# Altium schematic sheet Sheet3.SchDoc(Sheet3)
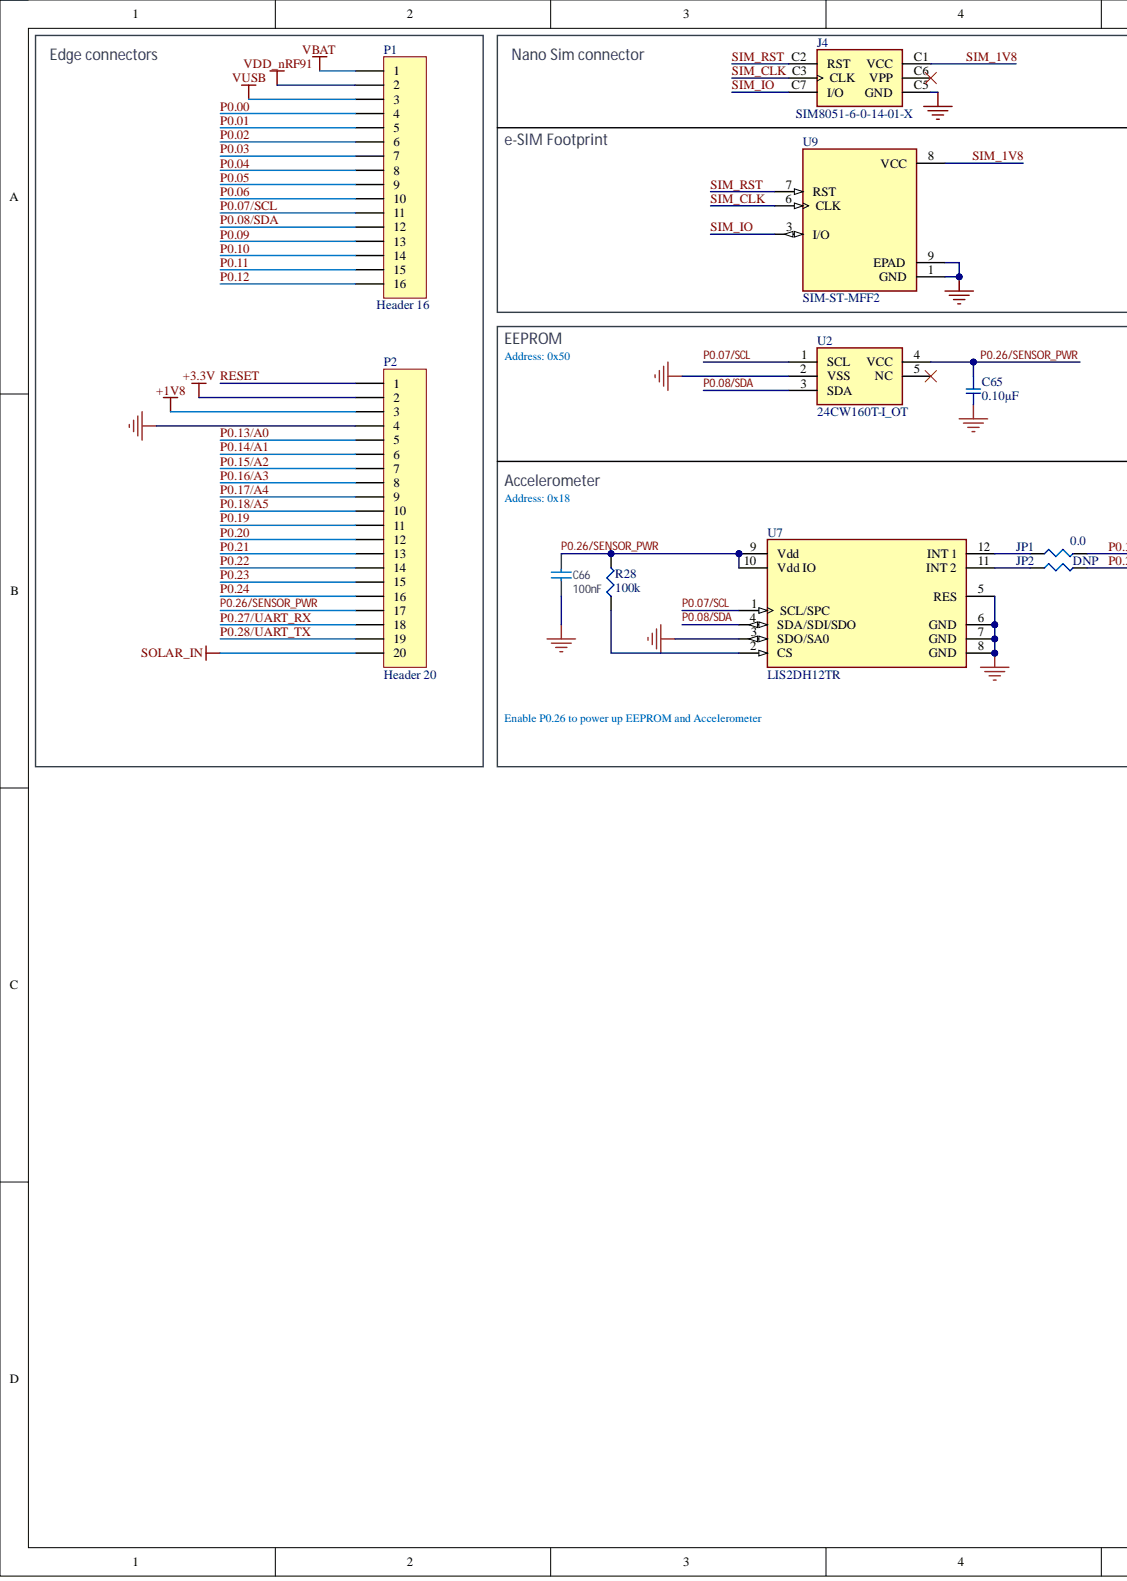
[diagram p0: Sheet3.SchDoc, left]
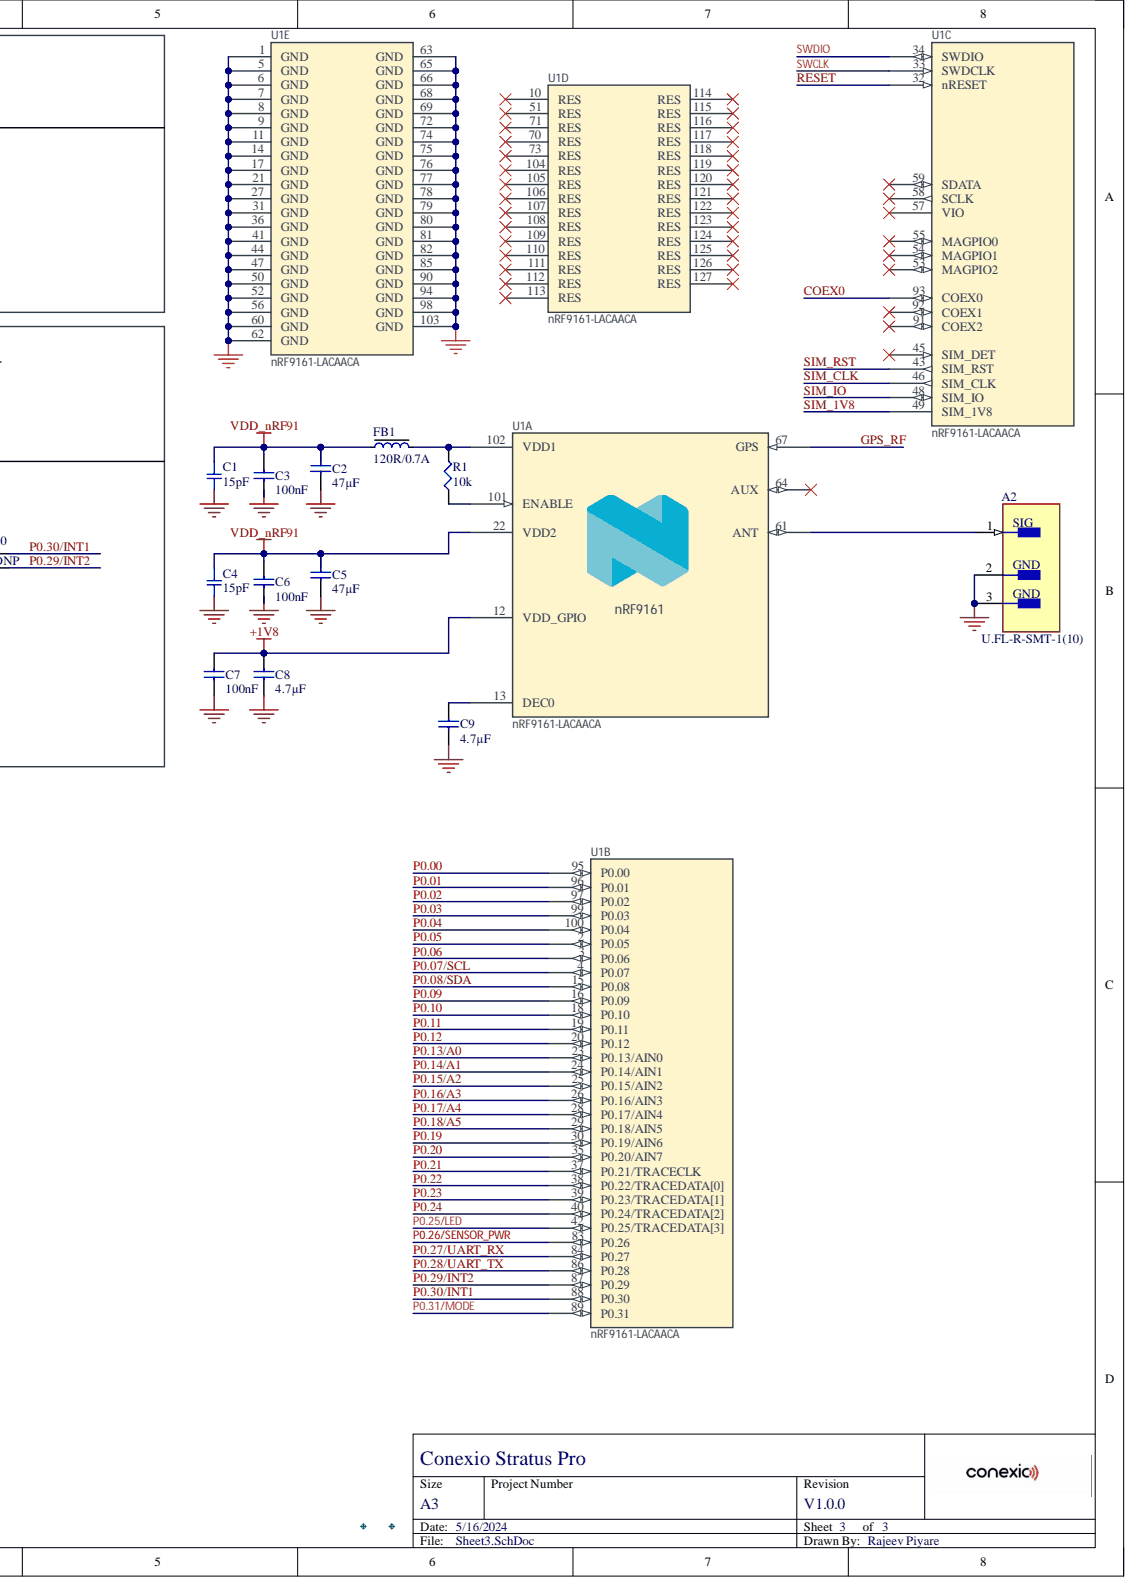
[diagram p1: Sheet3.SchDoc, right]

## Parts
A2  U.FL-R-SMT-1(10)
C1  15pF
C2  47µF
C3  100nF
C4  15pF
C5  47µF
C6  100nF
C7  100nF
C8  4.7µF
C9  4.7µF
C65  0.10µF
C66  100nF
FB1  120R/0.7A
J4  SIM8051-6-0-14-01-X
JP1  0.0
JP2  DNP
P1  Header 16
P2  Header 20
R1  10k
R28  100k
U1  nRF9161-LACAACA  (parts A,B,C,D,E)
U2  24CW160T-I_OT
U7  LIS2DH12TR
U9  SIM-ST-MFF2

## Nets
+1V8: C7.1 C8.1 P2.3 U1.12(VDD_GPIO)
+3.3V: P2.2
GND: A2.2 A2.3 C1.2 C2.2 C3.2 C4.2 C5.2 C6.2 C7.2 C8.2 C9.2 C65.2 C66.1 J4.C5(GND) P2.4 U1.1(GND) U1.5(GND) U1.6(GND) U1.7(GND) U1.8(GND) U1.9(GND) U1.11(GND) U1.14(GND) U1.17(GND) U1.21(GND) U1.27(GND) U1.31(GND) U1.36(GND) U1.41(GND) U1.44(GND) U1.47(GND) U1.50(GND) U1.52(GND) U1.56(GND) U1.60(GND) U1.62(GND) U1.63(GND) U1.65(GND) U1.66(GND) U1.68(GND) U1.69(GND) U1.72(GND) U1.74(GND) U1.75(GND) U1.76(GND) U1.77(GND) U1.78(GND) U1.79(GND) U1.80(GND) U1.81(GND) U1.82(GND) U1.85(GND) U1.90(GND) U1.94(GND) U1.98(GND) U1.103(GND) U2.2(VSS) U7.3(SDO/SA0) U7.5(RES) U7.6(GND) U7.7(GND) U7.8(GND) U9.1(GND) U9.9(EPAD)
SOLAR_IN: P2.20
VBAT: P1.1
VDD_nRF91: C1.1 C2.1 C3.1 C4.1 C5.1 C6.1 FB1.1 P1.2 U1.22(VDD2)
VUSB: P1.3
COEX0: U1.93(COEX0)
GPS_RF: U1.67(GPS)
P0.00: P1.4 U1.95(P0.00)
P0.01: P1.5 U1.96(P0.01)
P0.02: P1.6 U1.97(P0.02)
P0.03: P1.7 U1.99(P0.03)
P0.04: P1.8 U1.100(P0.04)
P0.05: P1.9 U1.2(P0.05)
P0.06: P1.10 U1.3(P0.06)
P0.07/SCL: P1.11 U1.4(P0.07) U2.1(SCL) U7.1(SCL/SPC)
P0.08/SDA: P1.12 U1.15(P0.08) U2.3(SDA) U7.4(SDA/SDI/SDO)
P0.09: P1.13 U1.16(P0.09)
P0.10: P1.14 U1.18(P0.10)
P0.11: P1.15 U1.19(P0.11)
P0.12: P1.16 U1.20(P0.12)
P0.13/A0: P2.5 U1.23(P0.13/AIN0)
P0.14/A1: P2.6 U1.24(P0.14/AIN1)
P0.15/A2: P2.7 U1.25(P0.15/AIN2)
P0.16/A3: P2.8 U1.26(P0.16/AIN3)
P0.17/A4: P2.9 U1.28(P0.17/AIN4)
P0.18/A5: P2.10 U1.29(P0.18/AIN5)
P0.19: P2.11 U1.30(P0.19/AIN6)
P0.20: P2.12 U1.35(P0.20/AIN7)
P0.21: P2.13 U1.37(P0.21/TRACECLK)
P0.22: P2.14 U1.38(P0.22/TRACEDATA[0])
P0.23: P2.15 U1.39(P0.23/TRACEDATA[1])
P0.24: P2.16 U1.40(P0.24/TRACEDATA[2])
P0.25/LED: U1.42(P0.25/TRACEDATA[3])
P0.26/SENSOR_PWR: C65.1 C66.2 P2.17 R28.2 U1.83(P0.26) U2.4(VCC) U7.9(Vdd) U7.10(Vdd_IO)
P0.27/UART_RX: P2.18 U1.84(P0.27)
P0.28/UART_TX: P2.19 U1.86(P0.28)
P0.29/INT2: JP2.2 U1.87(P0.29)
P0.30/INT1: JP1.2 U1.88(P0.30)
P0.31/MODE: U1.89(P0.31)
RESET: P2.1 U1.32(nRESET)
SIM_1V8: J4.C1(VCC) U1.49(SIM_1V8) U9.8(VCC)
SIM_CLK: J4.C3(CLK) U1.46(SIM_CLK) U9.6(CLK)
SIM_IO: J4.C7(I/O) U1.48(SIM_IO) U9.3(I/O)
SIM_RST: J4.C2(RST) U1.43(SIM_RST) U9.7(RST)
SWCLK: U1.33(SWDCLK)
SWDIO: U1.34(SWDIO)
NetA2_1: A2.1 U1.61(ANT)
NetC9_1: C9.1 U1.13(DEC0)
NetFB1_2: FB1.2 R1.2 U1.102(VDD1)
NetJP1_1: JP1.1 U7.12(INT_1)
NetJP2_1: JP2.1 U7.11(INT_2)
NetR1_1: R1.1 U1.101(ENABLE)
NetR28_1: R28.1 U7.2(CS)

Not connected: J4 C6(VPP); U1 10(RES) 45(SIM_DET) 51(RES) 53(MAGPIO2) 54(MAGPIO1) 55(MAGPIO0) 57(VIO) 58(SCLK) 59(SDATA) 64(AUX) 70(RES) 71(RES) 73(RES) 91(COEX2) 92(COEX1) 104(RES) 105(RES) 106(RES) 107(RES) 108(RES) 109(RES) 110(RES) 111(RES) 112(RES) 113(RES) 114(RES) 115(RES) 116(RES) 117(RES) 118(RES) 119(RES) 120(RES) 121(RES) 122(RES) 123(RES) 124(RES) 125(RES) 126(RES) 127(RES); U2 5(NC)

## Library data of the parts
A2 = CMP-2000-05152-3: CONN U.FL RCPT STR 50 OHM SMD; Body Material: Bronze; Body Plating: Silver; Connector Type: Coaxial; Dielectric Material: Polymer; Frequency: 6GHz; Gender: Male; Impedance: 50R; Insulation Resistance: 500MR; Component Kind: Standard; Contact Material: Brass; Contact Plating: Gold; Depth: 3.1mm; Fastening Type: Snap; Housing Color: Beige; Lead Free: Lead Free; Length: 3mm; Library Name: Altium Content Vault; Max Frequency: 6GHz; Max Operating Temperature: 90°C; Min Operating Temperature: -40°C; Mount: Surface Mount; Orientation: Straight; Package Quantity: 2500; Packaging: Cut Tape; Radiation Hardening: No; REACH SVHC: No SVHC; Series: U.FL; Termination: Solder; Weight: 0.001764oz; Wire/Cable Type: Coaxial
C1 C4 = GCM1885C1H150JA16D: CAP CER 15PF 50V NP0 0603; Manufacturer: Murata Electronics North America; @ qty: 0; Minimum Quantity: 1; Temperature Coefficient: C0G, NP0; Tolerance: ±5%; Unit Price (USD): 0.1; Applications: Automotive; Component Kind: Standard; Datasheets: http://www.murata.com/~/media/webrenewal/support/library/catalog/products/capacitor/mlcc/c03e.ashx; Digi-Key Part Number: 490-4957-1-ND; Factory Stock: 0; Features: -; Height - Seated (Max): -; Image: //media.digikey.com/Renders/Murata%20Renders/0603%28GCM188%29.jpg; Lead Spacing: -; Lead Style: -; Library Name: capacitor_murata_0603.IntLib; Mounting Type: Surface Mount, MLCC; Operating Temperature: -55°C ~ 125°C; Package / Case: 0603 (1608 Metric); Packaging: Cut Tape (CT); Part Number: GCM1885C1H150JA16D; Part Status: Active; Quantity Available: 99209; Ratings: AEC-Q200; Series: GCM; Size / Dimension: 0.063" L x 0.031" W (1.60mm x 0.80mm); Thickness (Max): 0.035" (0.90mm); Value: 15pF; Voltage: 50V
C2 C5 = C0805-47µ-10V: Capacitor, X5R, ±20%, 10V; Manufacturer: Murata; Manufacturer Part Number: GRM21BR61A476ME15; Part number IPS: 00000004759; Artikkelnr Noca: N.A.; Database Table Name: Parts$; Pad Count: 2; Component Class: Capacitors; Component Kind: Standard; ComponentLink1Description: Datasheet; ComponentLink1URL: https://search.murata.co.jp/Ceramy/image/img/A01X/G101/ENG/GRM21BR61A476ME15-01A.pdf; ComponentLink2Description: Supplier link; ComponentLink2URL: N.A.; Footprint Doc: 0805; HelpURL: https://www.murata.com/en-global/products/productdetail?partno=GRM21BR61A476ME15%23; LatestRevisionDate: 2020-10-23; Library Name: AltiumLib.DbLib; Note: 10V; Part Number: C0805-47µ-10V; PartId: 100200288; Published: 2020-08-14; Supplier 1: Digi-Key; Supplier 2: N.A.; Supplier Part Number 1: 490-9961-1-ND; Supplier Part Number 2: N.A.; Value: 47µF
C3 C6 C7 = C0201-100n: Capacitor, X5R, ±10%; Part number IPS: 00000001248, 00000002505; Artikkelnr Noca: N.A.; Database Table Name: Parts$; Note: 16V; Pad Count: 2; Component Class: Capacitors; Component Kind: Standard; ComponentLink1Description: Datasheet; ComponentLink1URL: N.A.; ComponentLink2Description: Supplier link; ComponentLink2URL: N.A.; Footprint Doc: 0201; HelpURL: N.A.; LatestRevisionDate: 2017-11-09; Library Name: AltiumLib.DbLib; Manufacturer: N.A.; Manufacturer Part Number: N.A.; Part Number: C0201-100n; PartId: 100200078; Published: 2014-11-13; Supplier 1: N.A.; Supplier 2: N.A.; Supplier Part Number 1: N.A.; Supplier Part Number 2: N.A.; Value: 100nF
C8 C9 = C0603-4µ7: Capacitor, X5R, ±10%; Manufacturer: N.A.; Part number IPS: 00000007783; Artikkelnr Noca: 20069060; Database Table Name: Parts$; Note: 6.3V; Pad Count: 2; Component Class: Capacitors; Component Kind: Standard; ComponentLink1Description: Datasheet; ComponentLink1URL: N.A.; ComponentLink2Description: Supplier link; ComponentLink2URL: N.A.; Footprint Doc: 0603; HelpURL: N.A.; LatestRevisionDate: 2009-11-23; Library Name: AltiumLib.DbLib; Manufacturer Part Number: N.A.; Part Number: C0603-4µ7; PartId: 100200274; Published: 2009-05-08; Supplier 1: N.A.; Supplier 2: N.A.; Supplier Part Number 1: N.A.; Supplier Part Number 2: N.A.; Value: 4.7µF
C65 = GCJ188R71H104KA12D: CAP CER 0.1UF 50V X7R 0603; Manufacturer: Murata Electronics North America; @ qty: 0; Minimum Quantity: 1; Tolerance: ±10%; Unit Price (USD): 0.12; Applications: Automotive, Boardflex Sensitive; Component Kind: Standard; Datasheets: http://www.murata.com/~/media/webrenewal/support/library/catalog/products/capacitor/mlcc/c03e.ashx; Digi-Key Part Number: 490-5798-1-ND; Factory Stock: 0; Features: Soft Termination; Height - Seated (Max): -; Image: //media.digikey.com/Renders/Murata%20Renders/0603%28LQG18%29.jpg; Lead Spacing: -; Lead Style: -; Library Name: capacitor_murata_0603.IntLib; Mounting Type: Surface Mount, MLCC; Operating Temperature: -55°C ~ 125°C; Package / Case: 0603 (1608 Metric); Packaging: Cut Tape (CT); Part Number: GCJ188R71H104KA12D; Part Status: Active; Quantity Available: 163695; Ratings: AEC-Q200; Series: GCJ; Size / Dimension: 0.063" L x 0.031" W (1.60mm x 0.80mm); Temperature Coefficient: X7R; Thickness (Max): 0.035" (0.90mm); Value: 0.10µF; Voltage: 50V
C66 = C0201-100n-16V-X6S-MSASE063AC6104KFNA01: Capacitor, X6S, ±10%, 16V; Manufacturer: Taiyo Yuden; Part number NEMS: 100200544; Artikkelnr Noca: N.A.; Component Status: Active; Database Table Name: Parts$; Pad Count: 2; Component Class: Capacitors; Component Kind: Standard; ComponentLink1Description: Datasheet; ComponentLink1URL: https://www.yuden.co.jp/productdata/catalog/mlcc06_e.pdf; ComponentLink2Description: Supplier link; ComponentLink2URL: N.A.; Footprint Doc: 0201; HelpURL: https://ds.yuden.co.jp/TYCOMPAS/ut/detail?pn=MSASE063AC6104KFNA01&u=M; LatestRevisionDate: 2023-03-22; Library Name: AltiumLib.DbLib; Manufacturer Part Number: MSASE063AC6104KFNA01; Note: 16V; Part Number: C0201-100n-16V-X6S-MSASE063AC6104KFNA01; Part number IPS: N.A.; Part number Norautron: N.A.; PartId: 100200544; Published: 2023-03-22; Supplier 1: Digi-Key; Supplier 2: N.A.; Supplier Part Number 1: 587-6001-1-ND; Supplier Part Number 2: N.A.; Value: 100nF; Author: rubr
FB1 = FB0402-120R@100M-700mA: Ferrite Bead, 120 Ohm @ 100MHz, 700mA, 130 mOhm Max; Manufacturer: Murata; Manufacturer Part Number: BLM15AX121SN1; Part number IPS: 00000004487; Artikkelnr Noca: N.A.; Database Table Name: Parts$; Pad Count: 2; Component Class: Inductors; Component Kind: Standard; ComponentLink1Description: Datasheet; ComponentLink1URL: https://www.murata.com/en-us/products/productdata/8796740059166/ENFA0018.pdf; ComponentLink2Description: Supplier link; ComponentLink2URL: N.A.; Footprint Doc: 0402; HelpURL: https://www.murata.com/products/productdetail?partno=BLM15AX121SN1%23; LatestRevisionDate: 2020-04-28; Library Name: AltiumLib.DbLib; Note: N.A.; Part Number: FB0402-120R@100M-700mA; PartId: 100300100; Published: 2020-04-28; Supplier 1: Digi-Key; Supplier 2: N.A.; Supplier Part Number 1: 490-5439-1-ND; Supplier Part Number 2: N.A.; Value: 120R/0.7A
J4 = SIM8051-6-0-14-01-X-REVD: 6 Position Card Connector NANO SIM Surface Mount, Right Angle Gold; MF: Global Connector Technology; MP: SIM8051-6-0-14-01-A; Availability: Unavailable; Component Kind: Standard; Library Name: SIM8051-6-0-14-01-X-REVD.SchLib; Package: None; Price: None; SnapEDA_Link: https://www.snapeda.com/parts/SIM8051-6-0-14-01-A/Global%20Connector%20Technology/view-part/511677/?ref=snap
JP1 = ERJ-3GEY0R00V: RES SMD 0.0OHM JUMPER 1/10W 0603; Manufacturer: Panasonic Electronic Components; @ qty: 1500; Factory Stock: 0; Minimum Quantity: 1; Power (Watts): 0.1W, 1/10W; Unit Price (USD): 0.00352; Component Kind: Standard; Composition: Thick Film; Datasheets: http://industrial.panasonic.com/www-cgi/jvcr13pz.cgi?E+PZ+3+AOA0001+ERJ3GEY0R00V+7+WW; Digi-Key Part Number: P0.0GCT-ND; Failure Rate: -; Features: Automotive AEC-Q200; Height - Seated (Max): 0.022" (0.55mm); Image: //media.digikey.com/Photos/Panasonic%20Photos/MFG_ERJ-P6W%20Series.jpg; Library Name: Panasonic_ERJ_0603.IntLib; Number of Terminations: 2; Operating Temperature: -55°C ~ 155°C; Package / Case: 0603 (1608 Metric); Packaging: Cut Tape (CT); Part Number: ERJ-3GEY0R00V; Part Status: Active; Quantity Available: 4813372; Series: ERJ; Size / Dimension: 0.063" L x 0.031" W (1.60mm x 0.80mm); Supplier Device Package: 0603; Temperature Coefficient: -; Tolerance: Jumper; Value: 0.0
JP2 = ERJ-3GEY0R00V: DO NOT PLACE RES SMD 0.0OHM JUMPER 1/10W 0603; Manufacturer: Panasonic Electronic Components; @ qty: 1500; Factory Stock: 0; Minimum Quantity: 1; Power (Watts): 0.1W, 1/10W; Unit Price (USD): 0.00352; Value: 0.0; Component Kind: Standard; Composition: Thick Film; Datasheets: http://industrial.panasonic.com/www-cgi/jvcr13pz.cgi?E+PZ+3+AOA0001+ERJ3GEY0R00V+7+WW; Digi-Key Part Number: P0.0GCT-ND; Failure Rate: -; Features: Automotive AEC-Q200; Height - Seated (Max): 0.022" (0.55mm); Image: //media.digikey.com/Photos/Panasonic%20Photos/MFG_ERJ-P6W%20Series.jpg; Library Name: Panasonic_ERJ_0603.IntLib; Number of Terminations: 2; Operating Temperature: -55°C ~ 155°C; Package / Case: 0603 (1608 Metric); Packaging: Cut Tape (CT); Part Number: ERJ-3GEY0R00V; Part Status: Active; Quantity Available: 4813372; Series: ERJ; Size / Dimension: 0.063" L x 0.031" W (1.60mm x 0.80mm); Supplier Device Package: 0603; Temperature Coefficient: -; Tolerance: Jumper
P1 = Header 16: Header, 16-Pin; Component Kind: Standard; LatestRevisionDate: 17-Jul-2002; LatestRevisionNote: Re-released for DXP Platform.; Library Name: Miscellaneous Connectors.IntLib; Publisher: Altium Limited
P2 = Header 20: Header, 20-Pin; Component Kind: Standard; LatestRevisionDate: 17-Jul-2002; LatestRevisionNote: Re-released for DXP Platform.; Library Name: Miscellaneous Connectors.IntLib; Publisher: Altium Limited
R1 = ERJ-3EKF1002V: RES SMD 10K OHM 1% 1/10W 0603; Manufacturer: Panasonic Electronic Components; @ qty: 1500; Factory Stock: 0; Minimum Quantity: 1; Power (Watts): 0.1W, 1/10W; Temperature Coefficient: ±100ppm/°C; Unit Price (USD): 0.00379; Component Kind: Standard; Composition: Thick Film; Datasheets: http://industrial.panasonic.com/www-cgi/jvcr13pz.cgi?E+PZ+3+AOA0002+ERJ3EKF1002V+7+WW; Digi-Key Part Number: P10.0KHCT-ND; Failure Rate: -; Features: Automotive AEC-Q200; Height - Seated (Max): 0.022" (0.55mm); Image: //media.digikey.com/Photos/Panasonic%20Photos/MFG_ERJ-P6W%20Series.jpg; Library Name: Panasonic_ERJ_0603.IntLib; Number of Terminations: 2; Operating Temperature: -55°C ~ 155°C; Package / Case: 0603 (1608 Metric); Packaging: Cut Tape (CT); Part Number: ERJ-3EKF1002V; Part Status: Active; Quantity Available: 6703141; Series: ERJ; Size / Dimension: 0.063" L x 0.031" W (1.60mm x 0.80mm); Supplier Device Package: 0603; Tolerance: ±1%; Value: 10k
R28 = ERJ-3EKF1003V: RES SMD 100K OHM 1% 1/10W 0603; Manufacturer: Panasonic Electronic Components; @ qty: 1500; Factory Stock: 0; Minimum Quantity: 1; Power (Watts): 0.1W, 1/10W; Temperature Coefficient: ±100ppm/°C; Unit Price (USD): 0.00379; Component Kind: Standard; Composition: Thick Film; Datasheets: http://industrial.panasonic.com/www-cgi/jvcr13pz.cgi?E+PZ+3+AOA0002+ERJ3EKF1003V+7+WW; Digi-Key Part Number: P100KHCT-ND; Failure Rate: -; Features: Automotive AEC-Q200; Height - Seated (Max): 0.022" (0.55mm); Image: //media.digikey.com/Photos/Panasonic%20Photos/MFG_ERJ-P6W%20Series.jpg; Library Name: Panasonic_ERJ_0603.IntLib; Number of Terminations: 2; Operating Temperature: -55°C ~ 155°C; Package / Case: 0603 (1608 Metric); Packaging: Cut Tape (CT); Part Number: ERJ-3EKF1003V; Part Status: Active; Quantity Available: 2179804; Series: ERJ; Size / Dimension: 0.063" L x 0.031" W (1.60mm x 0.80mm); Supplier Device Package: 0603; Tolerance: ±1%; Value: 100k
U1 = nRF9161-LACAACA: Low power System-in-Package with integrated LTE-M/NB-IoT modem and GPS; Manufacturer: Nordic Semiconductor; Part number NEMS: 102000289; Artikkelnr Noca: N.A.; Component Status: Active; Pad Count: 159; Component Class: Nordic_Devices; Component Kind: Standard; ComponentLink1Description: Datasheet; ComponentLink1URL: N.A.; ComponentLink2Description: Supplier link; ComponentLink2URL: N.A.; Footprint Doc: LGA-159; HelpURL: N.A.; LatestRevisionDate: 2023-05-10; Library Name: pca10153.IntLib; Manufacturer Part Number: nRF9161-LACAACA; Note: N.A.; Part Number: nRF9161-LACAACA; Part number IPS: N.A.; Part number Norautron: N.A.; PartId: 102000289; Published: 2023-05-10; Supplier 1: Nordic Semiconductor; Supplier 2: N.A.; Supplier Part Number 1: nRF9161-LACAACA; Supplier Part Number 2: N.A.; Value: nRF9161-LACAACA; Author: rubr
U2 = 24CW160T-I_OT: Integrated Circuit; Manufacturer_Name: Microchip; Manufacturer_Part_Number: 24CW160T-I/OT; Arrow Part Number: 24CW160T-I/OT; Arrow Price/Stock: https://www.arrow.com/en/products/24cw160t-iot/microchip-technology?region=nac; Component Kind: Standard; Datasheet Link: http://ww1.microchip.com/downloads/en/DeviceDoc/24CW16X-24CW32X-24CW64X-24CW128X-Data-Sheet-20005772B.pdf; Height: 1.45mm; Mouser Part Number: 579-24CW160T-I/OT; Mouser Price/Stock: https://www.mouser.co.uk/ProductDetail/Microchip-Technology/24CW160T-I-OT?qs=F5EMLAvA7IAMss1CRRO1Kw%3D%3D
U7 = CMP-2000-05369-2: ACCEL 2-16G I2C/SPI 12LGA; Axis: X, Y, Z; Bandwidth: 672Hz; Interface: I2C; Max Supply Voltage: 3.6V; Min Supply Voltage: 1.71V; Output Type: SPI; Case/Package: LGA; Component Kind: Standard; Contact Plating: Gold; Height: 1mm; Lead Free: Lead Free; Length: 2.15mm; Library Name: Altium Content Vault; Max Operating Temperature: 85°C; Min Operating Temperature: -40°C; Mount: Surface Mount; Number of Pins: 12; Packaging: Cut Tape; Radiation Hardening: No; REACH SVHC: No SVHC; Width: 2.15mm
U9 = SIM-ST-MFF2: GLOBAL IOT EMBEDDED SIM CHIP; MF: {'manufacturer': u'Hologram, Inc.'}; Availability: Unavailable; Component Kind: Standard; MP: SIM-ST-MFF2; Package: None; Price: None
